# Altium schematic sheet 02_Antenna.SchDoc(02_Antenna)
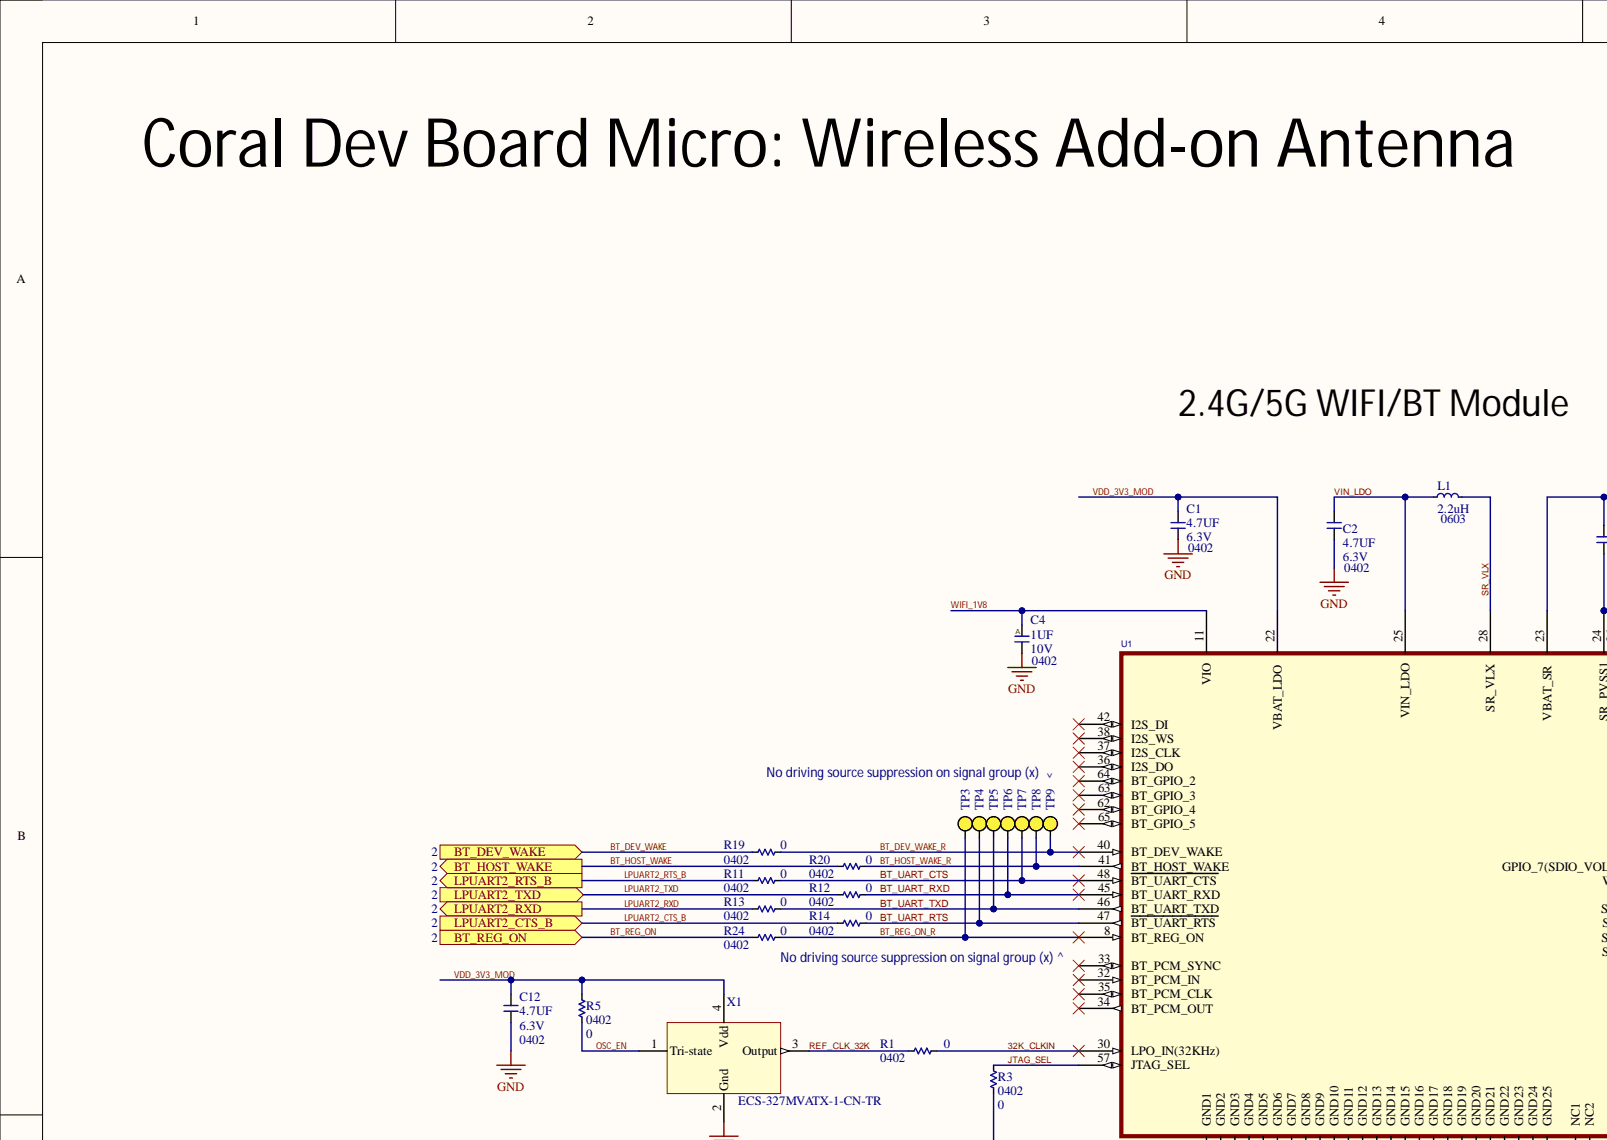
[diagram p0: 02_Antenna.SchDoc, top left]
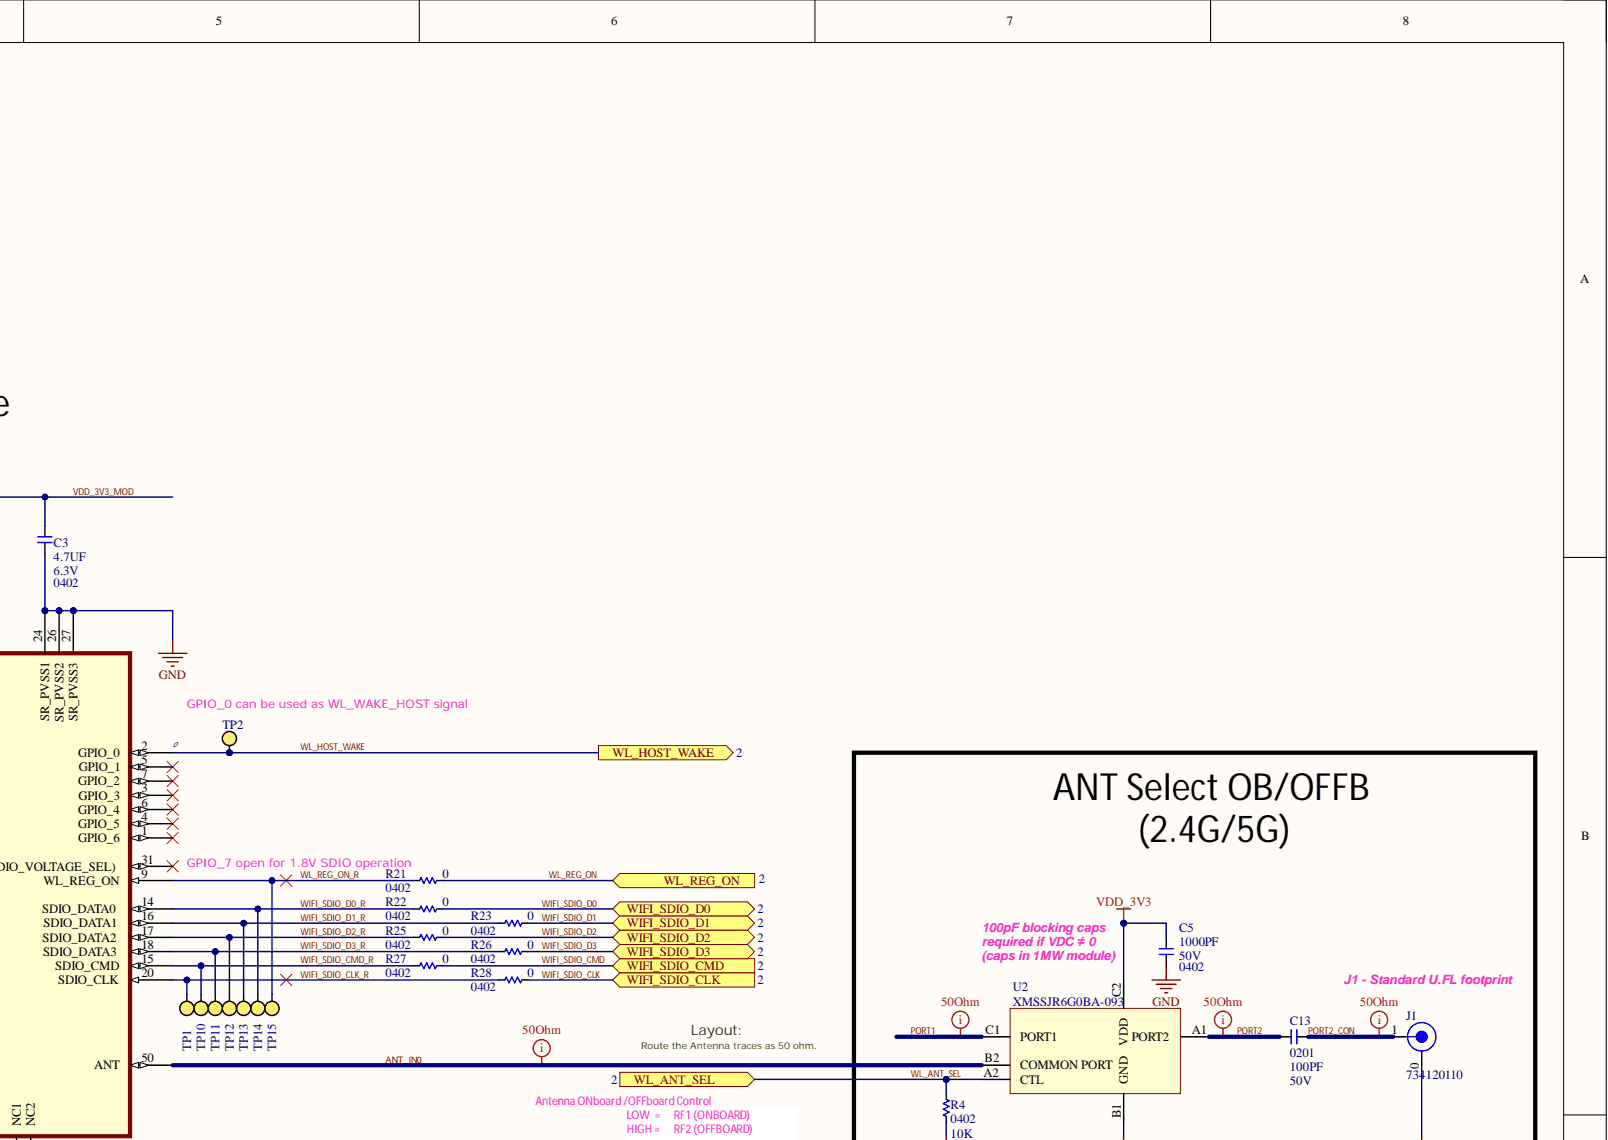
[diagram p1: 02_Antenna.SchDoc, top right]
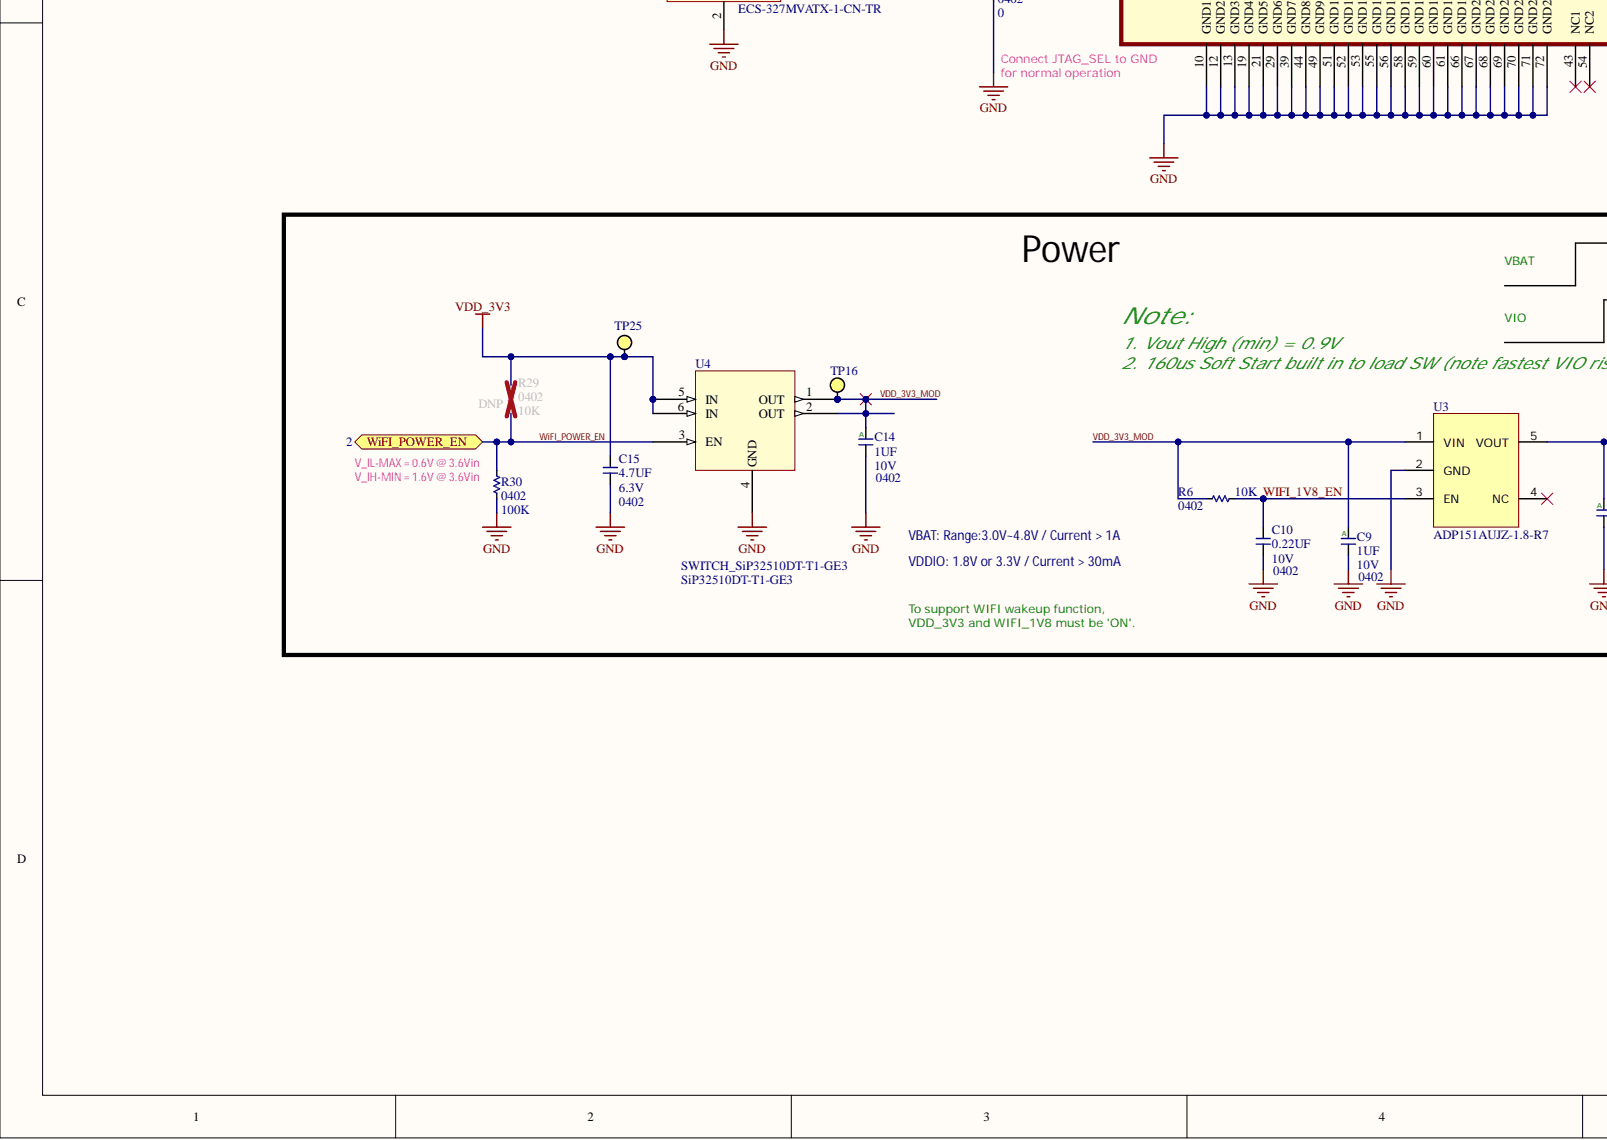
[diagram p2: 02_Antenna.SchDoc, bottom left]
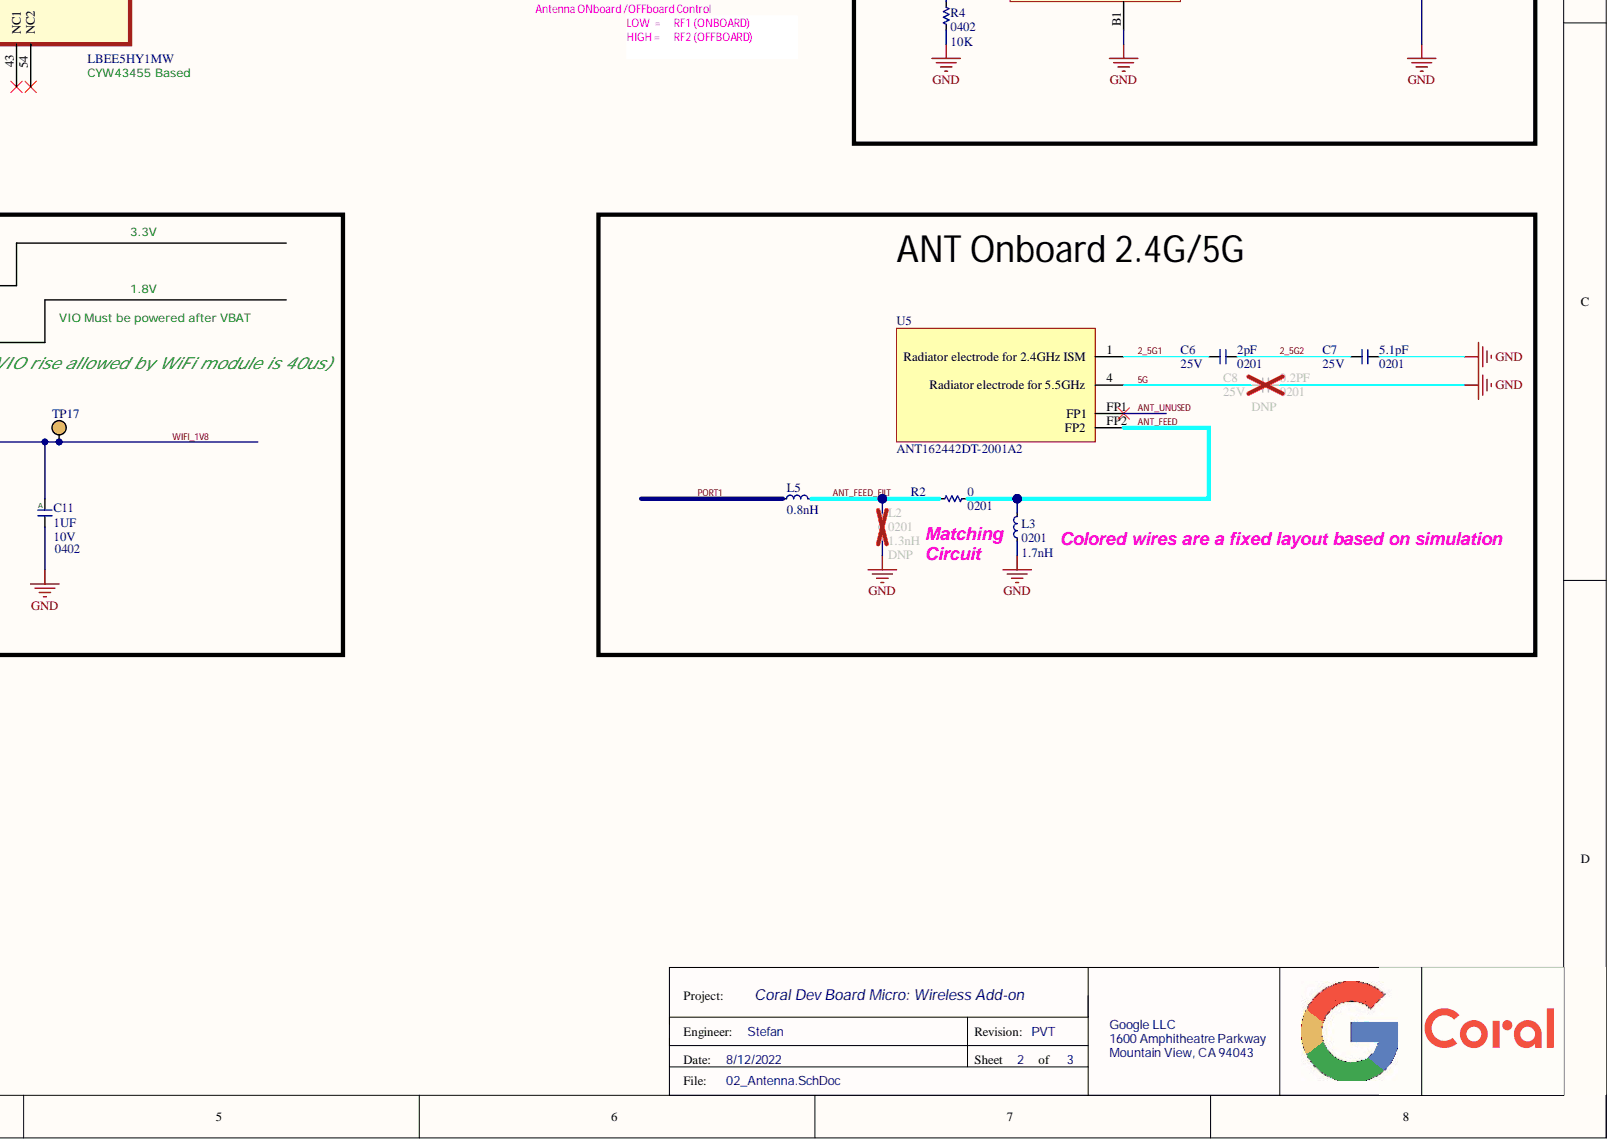
[diagram p3: 02_Antenna.SchDoc, bottom right]

## Parts
C1  value 4.7UF  Package: 0402  Voltage: 6.3V  [C0402]  (hidden comment: __Template_Cap)
C2  value 4.7UF  Package: 0402  Voltage: 6.3V  [C0402]  (hidden comment: __Template_Cap)
C3  value 4.7UF  Package: 0402  Voltage: 6.3V  [C0402]  (hidden comment: __Template_Cap)
C4  value 1UF  Package: 0402  Voltage: 10V  [C0402]  (hidden comment: __Template_Cap_Auto)
C5  value 1000PF  Package: 0402  Voltage: 50V  [C0402]  (hidden comment: __Template_Cap)
C6  value 2pF  Package: 0201  Voltage: 25V  [C0201_H0.33MM_V1]  (hidden comment: __Template_Cap)
C7  value 5.1pF  Package: 0201  Voltage: 25V  [C0201_H0.33MM_V1]  (hidden comment: __Template_Cap)
C8  value 0.2PF  Package: 0201  Voltage: 25V  [C0201]  (hidden comment: __Template_Cap)
C9  value 1UF  Package: 0402  Voltage: 10V  [C0402]  (hidden comment: __Template_Cap_Auto)
C10  value 0.22UF  Package: 0402  Voltage: 10V  [C0402]  (hidden comment: __Template_Cap)
C11  value 1UF  Package: 0402  Voltage: 10V  [C0402]  (hidden comment: __Template_Cap_Auto)
C12  value 4.7UF  Package: 0402  Voltage: 6.3V  [C0402]  (hidden comment: __Template_Cap)
C13  value 100PF  Package: 0201  Voltage: 50V  [C0201Y]  (hidden comment: __Template_Cap)
C14  value 1UF  Package: 0402  Voltage: 10V  [C0402]  (hidden comment: __Template_Cap_Auto)
C15  value 4.7UF  Voltage: 6.3V  [C0402]  (hidden comment: __Template_Cap)
J1  MFG P/N: 734120110  [U.FL_50_OHM_PCB_MOLEX_0734120110]
L1  value 2.2uH  Package: 0603  [L0603_H0.95MM]  (hidden comment: __Template_Inductor)
L2  value 1.3nH  Package: 0201  [L0201_H0.33MM]  (hidden comment: __Template_Inductor)
L3  value 1.7nH  Package: 0201  [L0201_H0.33MM]  (hidden comment: __Template_Inductor)
L5  value 0.8nH  [L0201_H0.32MM]  (hidden comment: __Template_Inductor)
R1  value 0  Package: 0402  [R0402]
R2  value 0  Package: 0201  [R0201]
R3  value 0  Package: 0402  [R0402]
R4  value 10K  Package: 0402  [R0402]
R5  value 0  Package: 0402  [R0402]
R6  value 10K  Package: 0402  [R0402]
R11  value 0  Package: 0402  [R0402]
R12  value 0  Package: 0402  [R0402]
R13  value 0  Package: 0402  [R0402]
R14  value 0  Package: 0402  [R0402]
R19  value 0  Package: 0402  [R0402]
R20  value 0  Package: 0402  [R0402]
R21  value 0  Package: 0402  [R0402]
R22  value 0  Package: 0402  [R0402]
R23  value 0  Package: 0402  [R0402]
R24  value 0  Package: 0402  [R0402]
R25  value 0  Package: 0402  [R0402]
R26  value 0  Package: 0402  [R0402]
R27  value 0  Package: 0402  [R0402]
R28  value 0  Package: 0402  [R0402]
R29  value 10K  Package: 0402  [R0402]
R30  value 100K  Package: 0402  [R0402]
TP1  TESTPAD_30MIL  [TESTPAD_SMT_30MIL]  (value not drawn)  (no BOM)
TP2  TESTPAD_30MIL  [TESTPAD_SMT_30MIL]  (value not drawn)  (no BOM)
TP3  TESTPAD_30MIL  [TESTPAD_SMT_30MIL]  (value not drawn)  (no BOM)
TP4  TESTPAD_30MIL  [TESTPAD_SMT_30MIL]  (value not drawn)  (no BOM)
TP5  TESTPAD_30MIL  [TESTPAD_SMT_30MIL]  (value not drawn)  (no BOM)
TP6  TESTPAD_30MIL  [TESTPAD_SMT_30MIL]  (value not drawn)  (no BOM)
TP7  TESTPAD_30MIL  [TESTPAD_SMT_30MIL]  (value not drawn)  (no BOM)
TP8  TESTPAD_30MIL  [TESTPAD_SMT_30MIL]  (value not drawn)  (no BOM)
TP9  TESTPAD_30MIL  [TESTPAD_SMT_30MIL]  (value not drawn)  (no BOM)
TP10  TESTPAD_30MIL  [TESTPAD_SMT_30MIL]  (value not drawn)  (no BOM)
TP11  TESTPAD_30MIL  [TESTPAD_SMT_30MIL]  (value not drawn)  (no BOM)
TP12  TESTPAD_30MIL  [TESTPAD_SMT_30MIL]  (value not drawn)  (no BOM)
TP13  TESTPAD_30MIL  [TESTPAD_SMT_30MIL]  (value not drawn)  (no BOM)
TP14  TESTPAD_30MIL  [TESTPAD_SMT_30MIL]  (value not drawn)  (no BOM)
TP15  TESTPAD_30MIL  [TESTPAD_SMT_30MIL]  (value not drawn)  (no BOM)
TP16  TESTPAD_30MIL  [TESTPAD_SMT_30MIL]  (value not drawn)  (no BOM)
TP17  TESTPAD_30MIL  [TESTPAD_SMT_30MIL]  (value not drawn)  (no BOM)
TP25  TESTPAD_30MIL  [TESTPAD_SMT_30MIL]  (value not drawn)  (no BOM)
U1  Mfg: LBEE5HY1MW  [MODULE_LBEE5HY1MW]  (hidden comment: MODULE_LBEE5HY1MW)
U2  MFG P/N: XMSSJR6G0BA-093  [BGA6C40X40+2X3_70X110X52]  (hidden comment: SWITCH_MURATA_XMSSJR6G0BA-093)
U3  MFG P/N: ADP151AUJZ-1.8-R7  [5TSOT23]  (hidden comment: LDO_ADP151AUJZ-1.8-R7)
U4  SWITCH_SiP32510DT-T1-GE3  MFG P/N: SiP32510DT-T1-GE3  [SOT95P285X110-6]
U5  Mfg P/N: ANT162442DT-2001A2  [ANTENNA_ANT162442DT-2001A2]  (hidden comment: ANTENNA_ANT162442DT-2001A2)
X1  Mfg P/N: ECS-327MVATX-1-CN-TR  [OSC_ECS-327MVATX-1-CN-TR-4]  (hidden comment: XTAL_ECS-327MVATX-1-CN-TR)

## Ports
BT_DEV_WAKE, BT_HOST_WAKE, BT_REG_ON, LPUART2_CTS_B, LPUART2_RTS_B, LPUART2_RXD, LPUART2_TXD, WiFI_POWER_EN, WIFI_SDIO_CLK, WIFI_SDIO_CMD, WIFI_SDIO_D0, WIFI_SDIO_D1, WIFI_SDIO_D2, WIFI_SDIO_D3, WL_ANT_SEL, WL_HOST_WAKE, WL_REG_ON

## Nets
GND: C1.2 C2.2 C3.2 C4.2 C5.2 C7.2 C8.2 C9.2 C10.2 C11.2 C12.2 C14.2 C15.2 J1.0 L2.1 L3.1 R3.1 R4.1 R30.1 U1.10(GND1) U1.12(GND2) U1.13(GND3) U1.19(GND4) U1.21(GND5) U1.24(SR_PVSS1) U1.26(SR_PVSS2) U1.27(SR_PVSS3) U1.29(GND6) U1.39(GND7) U1.44(GND8) U1.49(GND9) U1.51(GND10) U1.52(GND11) U1.53(GND12) U1.55(GND13) U1.56(GND14) U1.58(GND15) U1.59(GND16) U1.60(GND17) U1.61(GND18) U1.66(GND19) U1.67(GND20) U1.68(GND21) U1.69(GND22) U1.70(GND23) U1.71(GND24) U1.72(GND25) U2.B1(GND) U3.2(GND) U4.4(GND) X1.2(Gnd)
VDD_3V3: C5.1 C15.1 R29.2 TP25.1 U2.C2(VDD) U4.5(IN) U4.6(IN)
2_5G1: C6.1 U5.1(Radiator_electrode_for_2.4GHz_ISM)
2_5G2: C6.2 C7.1
5G: C8.1 U5.4(Radiator_electrode_for_5.5GHz)
32K_CLKIN: R1.2 U1.30(LPO_IN(32KHz))
ANT_FEED: L3.2 R2.2 U5.FP2
ANT_FEED_FILT: L2.2 L5.2 R2.1
ANT_IN0: U1.50(ANT) U2.B2(COMMON_PORT)
ANT_UNUSED: U5.FP1
BT_DEV_WAKE: R19.2 ; port BT_DEV_WAKE
BT_DEV_WAKE_R: R19.1 TP9.1 U1.40(BT_DEV_WAKE)
BT_HOST_WAKE: R20.2 ; port BT_HOST_WAKE
BT_HOST_WAKE_R: R20.1 TP8.1 U1.41(BT_HOST_WAKE)
BT_REG_ON: R24.2 ; port BT_REG_ON
BT_REG_ON_R: R24.1 TP3.1 U1.8(BT_REG_ON)
BT_UART_CTS: R11.1 TP7.1 U1.48(BT_UART_CTS)
BT_UART_RTS: R14.1 TP4.1 U1.47(BT_UART_RTS)
BT_UART_RXD: R12.1 TP6.1 U1.45(BT_UART_RXD)
BT_UART_TXD: R13.1 TP5.1 U1.46(BT_UART_TXD)
JTAG_SEL: R3.2 U1.57(JTAG_SEL)
LPUART2_CTS_B: R14.2 ; port LPUART2_CTS_B
LPUART2_RTS_B: R11.2 ; port LPUART2_RTS_B
LPUART2_RXD: R13.2 ; port LPUART2_RXD
LPUART2_TXD: R12.2 ; port LPUART2_TXD
OSC_EN: R5.1 X1.1(Tri-state)
PORT1: L5.1 U2.C1(PORT1)
PORT2: C13.1 U2.A1(PORT2)
PORT2_CON: C13.2 J1.1
REF_CLK_32K: R1.1 X1.3(Output)
SR_VLX: L1.2 U1.28(SR_VLX)
VDD_3V3_MOD: C1.1 C3.1 C9.1 C12.1 C14.1 R5.2 R6.1 TP16.1 U1.22(VBAT_LDO) U1.23(VBAT_SR) U3.1(VIN) U4.1(OUT) U4.2(OUT) X1.4(Vdd)
VIN_LDO: C2.1 L1.1 U1.25(VIN_LDO)
WIFI_1V8: C4.1 C11.1 TP17.1 U1.11(VIO) U3.5(VOUT)
WIFI_1V8_EN: C10.1 R6.2 U3.3(EN)
WiFI_POWER_EN: R29.1 R30.2 U4.3(EN) ; port WiFI_POWER_EN
WIFI_SDIO_CLK: R28.1 ; port WIFI_SDIO_CLK
WIFI_SDIO_CLK_R: R28.2 TP1.1 U1.20(SDIO_CLK)
WIFI_SDIO_CMD: R27.1 ; port WIFI_SDIO_CMD
WIFI_SDIO_CMD_R: R27.2 TP10.1 U1.15(SDIO_CMD)
WIFI_SDIO_D0: R22.1 ; port WIFI_SDIO_D0
WIFI_SDIO_D0_R: R22.2 TP14.1 U1.14(SDIO_DATA0)
WIFI_SDIO_D1: R23.1 ; port WIFI_SDIO_D1
WIFI_SDIO_D1_R: R23.2 TP13.1 U1.16(SDIO_DATA1)
WIFI_SDIO_D2: R25.1 ; port WIFI_SDIO_D2
WIFI_SDIO_D2_R: R25.2 TP12.1 U1.17(SDIO_DATA2)
WIFI_SDIO_D3: R26.1 ; port WIFI_SDIO_D3
WIFI_SDIO_D3_R: R26.2 TP11.1 U1.18(SDIO_DATA3)
WL_ANT_SEL: R4.2 U2.A2(CTL) ; port WL_ANT_SEL
WL_HOST_WAKE: TP2.1 U1.2(GPIO_0) ; port WL_HOST_WAKE
WL_REG_ON: R21.1 ; port WL_REG_ON
WL_REG_ON_R: R21.2 TP15.1 U1.9(WL_REG_ON)

Not connected: U1 1(GPIO_6) 3(GPIO_3) 4(GPIO_5) 5(GPIO_1) 6(GPIO_4) 7(GPIO_2) 31(GPIO_7(SDIO_VOLTAGE_SEL)) 32(BT_PCM_IN) 33(BT_PCM_SYNC) 34(BT_PCM_OUT) 35(BT_PCM_CLK) 36(I2S_DO) 37(I2S_CLK) 38(I2S_WS) 42(I2S_DI) 43(NC1) 54(NC2) 62(BT_GPIO_4) 63(BT_GPIO_3) 64(BT_GPIO_2) 65(BT_GPIO_5); U3 4(NC)

## Library data of the parts
C1-C3 C12 C15 = 653: CAP, CER, X5R, 4.7uF, 10%, 6.3V, 0402; COMMON: 150-00307-00; Database Table Name: Capacitors; MFG: TDK; MFG P/N: C1005X5R0J475K050BC; PROXY: 150-03636-00; Component Kind: Standard; ComponentLink1Description: Datasheet; Dielectric: X5R; Height: 0.71mm T; ID: 653; Library Name: AltiumReleaseLibDB.DBLib; Status: Released; Tolerance: 10%; XPN: 150-00307-00
  C15: Package: 0402
C4 C9 C11 C14 = 26: CAP, CER, X5R, 1uF, 10V, 10%, 0402, AUTOGRADE; COMMON: 150-01126-00; Database Table Name: Capacitors; Gecko: 150-04432-00; MFG: Taiyo Yuden; MFG P/N: LMK105BJ105KVHF; PROXY: Use ID #574; Component Kind: Standard; ComponentLink1Description: Datasheet; Dielectric: X5R; Height: 0.55mm; ID: 26; Library Name: AltiumReleaseLibDB.DBLib; Status: Released; Supplier 1: Digi-Key; Supplier Part Number 1: 587-3712-1-ND; Tolerance: 10%; XPN: 150-01126-00
C5 = 58: CAP, CER, X7R, 1000pF, 50V, 10%, 0402; COMMON: 150-00779-00; Database Table Name: Capacitors; MFG: TDK; MFG P/N: C1005X7R1H102K050BA; PROXY: Alt ID #1746; Component Kind: Standard; ComponentLink1Description: Datasheet; Dielectric: X7R; Height: 0.56mm T; ID: 58; Library Name: AltiumReleaseLibDB.DBLib; Status: NRND; Tolerance: 10%; XPN: 150-00779-00
C6 = 1904: CAP, CER, C0G, 2pF, 25V, ±0.1pF, 0201; Database Table Name: Capacitors; Dielectric: C0G, NP0; MFG: Murata Electronics; MFG P/N: GJM0335C1E2R0BB01D; Tolerance: +/-0.1pF; Component Kind: Standard; ComponentLink1Description: Datasheet; ComponentLink1URL: https://search.murata.co.jp/Ceramy/image/img/A01X/G101/ENG/GJM0335C1E2R0BB01-01.pdf; Height: 0.33mm; HelpURL: https://www.digikey.com/en/products/detail/murata-electronics/GJM0335C1E2R0BB01D/2592545; ID: 1904; Library Name: AltiumReleaseLibDB.DBLib; Status: Released; Supplier 1: Digi-Key; Supplier Part Number 1: 490-11178-2-ND
C7 = 1905: CAP, CER, C0G, 5.1pF, 25V, ±0.1pF, 0201; Database Table Name: Capacitors; Dielectric: C0G, NP0; MFG: Murata Electronics; MFG P/N: GRM0335C1E5R1BA01D; Tolerance: +/-0.1pF; Component Kind: Standard; ComponentLink1Description: Datasheet; ComponentLink1URL: https://search.murata.co.jp/Ceramy/image/img/A01X/G101/ENG/GRM0335C1E5R1BA01-01.pdf; Height: 0.33mm; HelpURL: https://www.digikey.com/en/products/detail/murata-electronics/GRM0335C1E5R1BA01D/11618517; ID: 1905; Library Name: AltiumReleaseLibDB.DBLib; Status: Released; Supplier 1: Digi-Key; Supplier Part Number 1: 490-GRM0335C1E5R1BA01DTR-ND
C8 = 1229: CAP, CER, C0G, 0.2pF, 25V, +/-0.1pF, Hi Q, 0201, 0.33mm T; COMMON: 150-01282-00; Database Table Name: Capacitors; Dielectric: NP0; MFG: WALSIN TECHNOLOGY; MFG P/N: RF03N0R2B250CT; Tolerance: 0.1pF; Component Kind: Standard; ComponentLink1Description: Datasheet; DNP: DNP; Height: 0.33mm T; ID: 1229; Library Name: AltiumReleaseLibDB.DBLib; Status: Released; XPN: 150-01282-00
C10 = 198: CAP, CER, X5R, 0.22uF, 10V, 10%, 0402, 0.56mm T; COMMON: 150-00183-00; Database Table Name: Capacitors; MFG: AVX; MFG P/N: 0402ZD224KAT2A; Component Kind: Standard; ComponentLink1Description: Datasheet; Dielectric: X5R; Height: 0.56mm T; ID: 198; Library Name: AltiumReleaseLibDB.DBLib; Status: Released; Tolerance: 10%; XPN: 150-00183-00
C13 = 95: CAP, CER, C0G, 100pF, 50V, 2%, 0201, 0.33mm T; COMMON: 150-01399-00; Database Table Name: Capacitors; Dielectric: NP0; MFG: MURATA; MFG P/N: GRM0335C1H101GA01D; XPN: XS-10001168; Component Kind: Standard; ComponentLink1Description: Datasheet; Height: 0.33mm T; ID: 95; Library Name: AltiumReleaseLibDB.DBLib; Status: Released; Tolerance: 2%
J1 = 561: CONN, UMC, RCPT, 50 Ohm, 6GHz, VERT, SMT; COMMON: 210-00261-00; Database Table Name: Connectors; MFG: MOLEX; Component Kind: Standard; ID: 561; Library Name: AltiumReleaseLibDB.DBLib; RoHS Compliant: Yes; Status: Released; XPN: 210-00261-00
L1 = 543: IND, MLC, POWER, 2.2uH, 20%, 850mA, 0.38 DCR, 0603; COMMON: 180-00268-00; Database Table Name: Inductors/Coils/Chokes; MFG: ABRACON; MFG P/N: ASMPH-0603-2R2M-T; Component Kind: Standard; Height: 0.95mm; ID: 543; Idc max: 850mA; Library Name: AltiumReleaseLibDB.DBLib; Status: Released; XPN: 180-00268-00
L2 = 259: IND, COIL, 1.3nH, ±0.1nH, 600mA, 0.15 DCR, 0201; COMMON: 180-00542-00; Database Table Name: Inductors/Coils/Chokes; MFG: MURATA; MFG P/N: LQP03TN1N3B02D; Component Kind: Standard; DNP: DNP; Height: 0.33mm; ID: 259; Idc max: 600mA; Library Name: AltiumReleaseLibDB.DBLib; Status: Released; XPN: 180-00542-00
L3 = 372: IND, FIXED, NON-MAGNETIC, UNSHIELDED, 1.7 nH, ±0.1nH Tol, 600 mA, 150mOHM Max DCR, 0201; COMMON: 180-00898-00; Database Table Name: Inductors/Coils/Chokes; Idc max: 600mA; MFG: MURATA; MFG P/N: LQP03TN1N7B02D; XPN: XS-10002991; Component Kind: Standard; Height: 0.33mm; ID: 372; Library Name: AltiumReleaseLibDB.DBLib; Status: Released
L5 = 237: IND, 0.8nH, +/-0.05nH, 1000mA IDC, 0.05 OHM DCR, SRF=18000MHz, 0201, 0.32mm -T; COMMON: 180-00822-00; Database Table Name: Inductors/Coils/Chokes; MFG: MURATA; MFG P/N: LQP03TQ0N8W02D; XPN: XS-10002956; Component Kind: Standard; Height: 0.32mm; ID: 237; Idc max: 1000mA IDC; Library Name: AltiumReleaseLibDB.DBLib; Package: 0201; Status: Released
R1 R3 R5 R11-R14 R19-R28 = 8464: RES, JUMPER, 0, 1/10W, 0402; Database Table Name: Resistors; LOON: 470-02332-00; MFG: VISHAY; MFG P/N: MCS04020Z0000ZE000; PROXY: Use ID #7180; XPN: XS-10020297; Component Kind: Standard; ComponentLink1Description: Datasheet; ComponentLink1URL: http://www.vishay.com/docs/28705/mcx0x0xpro.pdf; Height: 0.015" (0.37mm); HelpURL: https://www.digikey.com/product-detail/en/vishay-beyschlag/MCS04020Z0000ZE000/MCS0402-0.0-ZZTR-ND/2607819; ID: 8464; Library Name: AltiumReleaseLibDB.DBLib; Power: 1/10W; Status: Released; Supplier 1: Digi-Key; Supplier Part Number 1: MCS0402-0.0-ZZTR-ND; Tolerance: JUMPER
R2 = 1: RES, JUMPER, 0, 1/20W, 0201; COMMON: 470-03574-00; Database Table Name: Resistors; Gecko: 470-17775-00; MFG: ROHM; MFG P/N: MCR006YRTJ000; PROXY: Alt ID #6940; Component Kind: Standard; Height: 0.26mm; ID: 1; Library Name: AltiumReleaseLibDB.DBLib; Power: 1/20W; Status: Obsolete; Supplier 1: Digi-Key; Supplier Part Number 1: RHM0.0CCCT-ND; Tolerance: JUMPER; XPN: 470-03574-00
R4 R6 R29 = 7152: RES, 10K, 5%, 1/16W, 0402, 0.37mm T; COMMON: 470-02958-00; Database Table Name: Resistors; MFG: TA-I TECHNOLOGY CO, LTD; MFG P/N: RM04JTN103; PROXY: Use ID #8484; Component Kind: Standard; Gecko: 470-02958-00; ID: 7152; Library Name: AltiumReleaseLibDB.DBLib; LOON: 470-02958-00; Power: 1/16W; Status: Released; Tolerance: 5%; XPN: 470-02958-00
  R29: DNP: DNP
R30 = 4977: RES, 100K, 1%, 1/16W, 0402; COMMON: 470-00580-00; Database Table Name: Resistors; KOI: 470-17076-00; MFG: YAGEO; MFG P/N: RC0402FR-07100KL; PROXY: 470-16075-00; Component Kind: Standard; Height: 0.40mm; ID: 4977; Library Name: AltiumReleaseLibDB.DBLib; Power: 1/16W; Status: Released; Supplier 1: Digi-Key; Supplier Part Number 1: 311-100KLRCT-ND; Tolerance: 1%; XPN: 470-00580-00
TP1-TP17 TP25 = 178: TESTPAD_SMT_30MIL; Database Table Name: Miscellaneous; MFG: _PCB Feature; ComponentLink1URL: _PCB Feature; ID: 178; Library Name: AltiumReleaseLibDB.DBLib; Status: Released
U1 = 122: RF, TCVR, WIFI/BLUETOOTH, CCK, DSSS, 433Mbps, SDIO/UART, 3.2V TO 4.2V, SMD; Database Table Name: Modules; Component Kind: Standard; COMPONENTLINK1DESCRIPTION: Datasheet; COMPONENTLINK1URL: https://wireless.murata.com/type-1mw.html; Height: 1.1mm; HelpURL: https://www.digikey.com/en/products/detail/murata-electronics/LBEE5HY1MW-230/10129248; ID: 122; Library Name: AltiumReleaseLibDB.DBLib; Mfg P/N: LBEE5HY1MW; MOUNTING TYPE: Surface Mount; RoHS Compliant: Yes; Status: Released; SUPPLIER 1: Digi-Key; Supplier Part Number 1: 490-18383-2-ND
U2 = 214: RF, SW, SPDT, CMOS, 0.01GHZ-6GHZ, SMD; Database Table Name: Miscellaneous; MFG: Murata Electronics; Component Kind: Standard; ComponentLink1Description: Datasheet; ComponentLink1URL: https://drive.google.com/file/d/1XWf-jftAKwo_2qGxGw9c7GfgPq4I2WGX/view?usp=sharing; Height: 0.52mm; ID: 214; Library Name: AltiumReleaseLibDB.DBLib; Mounting Type: SMD; RoHS Compliant: Yes; Status: Released
U3 = 10: IC, REGULATOR, BUCK, LDO, 1.8V, 0.2A, TSOT23-5; COMMON: 313-01891-00; Database Table Name: IC - PMIC; MFG: Analog Devices Inc; XPN: XS-10009640; Category: Integrated Circuits (ICs); Component Kind: Standard; ComponentLink1Description: Datasheet; ComponentLink1URL: http://www.analog.com/static/imported-files/data_sheets/ADP151.pdf; HelpURL: http://www.analog.com/static/imported-files/data_sheets/ADP151.pdf; ID: 10; Library Name: AltiumReleaseLibDB.DBLib; Mounting Type: SM; Package: SOT-23-5 Thin, TSOT-23-5; RoHS Compliant: Yes; Status: Released; Supplier 1: Digi-Key; Supplier Part Number 1: ADP151AUJZ-1.8-R7CT-ND
U4 = 655: IC, SW, LOAD, SIP32510DT-T1-GE3, N-CH, 1.2 TO 5.5 VL, 3A IO, SOT23-6; Database Table Name: IC - PMIC; MFG: Vishay Siliconix; Component Kind: Standard; ComponentLink1Description: Datasheet; ComponentLink1URL: http://www.vishay.com/docs/63577/sip32510.pdf; HelpURL: https://www.digikey.in/product-detail/en/vishay-siliconix/SIP32510DT-T1-GE3/SIP32510DT-T1-GE3CT-ND/6230055; ID: 655; Library Name: AltiumReleaseLibDB.DBLib; Mounting Type: SMT; Package: TSOT-23-6; RoHS Compliant: Yes; Status: Released; Supplier 1: Digi-Key; Supplier Part Number 1: SIP32510DT-T1-GE3CT-ND
U5 = 6: RF, ANTENNA, CHIP, 2.4GHZ TO 2.48GHZ, 5.15GHZ TO 5.85GHZ, UHF, SMD; Database Table Name: Radar_RF_PCB_Symbols; Mfg: TDK Corporation; Category: RF ANT; Component Kind: Standard; ComponentLink1Description: Datasheet; ComponentLink1URL: https://product.tdk.com/info/en/documents/data_sheet/rf_ant_ant162442dt-2001a2_summary_en.pdf; Height: 0.4mm; HelpURL: https://www.digikey.com/product-detail/en/tdk-corporation/ANT162442DT-2001A2/495-75558-1-ND/6590753; ID: 6; Library Name: AltiumReleaseLibDB.DBLib; Mounting Type: SMD; RoHS Compliant: Yes; Status: Released; Supplier 1: Digi-Key; Supplier Part Number 1: 495-75558-1-ND
X1 = 134: OSC, XO, 32.768KHZ, 1.6 TO 3.6 VIN, CMOS, SMD-4; Current - Supply (Max): 200µA; Database Table Name: Oscillator; Frequency Stability: ±25ppm; Function: Enable/Disable; Mfg: ECS Inc.; Voltage – Supply: 1.6V ~ 3.6V; Category: Oscillators; Component Kind: Standard; ComponentLink1Description: Datasheet; ComponentLink1URL: https://ecsxtal.com/store/pdf/ECS-327MVATX.pdf; Frequency: 32.768kHz; Height: 0.9mm; HelpURL: https://www.digikey.com/product-detail/en/ecs-inc/ECS-327MVATX-1-CN-TR/XC3153CT-ND/10239160; ID: 134; Library Name: AltiumReleaseLibDB.DBLib; Mounting Type: SMD; Operating Temperature: -40°C ~ 85°C; Package: 4-SMD, No Lead; RoHS Compliant: Yes; Status: Released; Supplier 1: Digi-Key; Supplier Part Number 1: XC3153CT-ND; Type: XO (Standard)
